# Altium schematic sheet SIB Ext Press.SchDoc
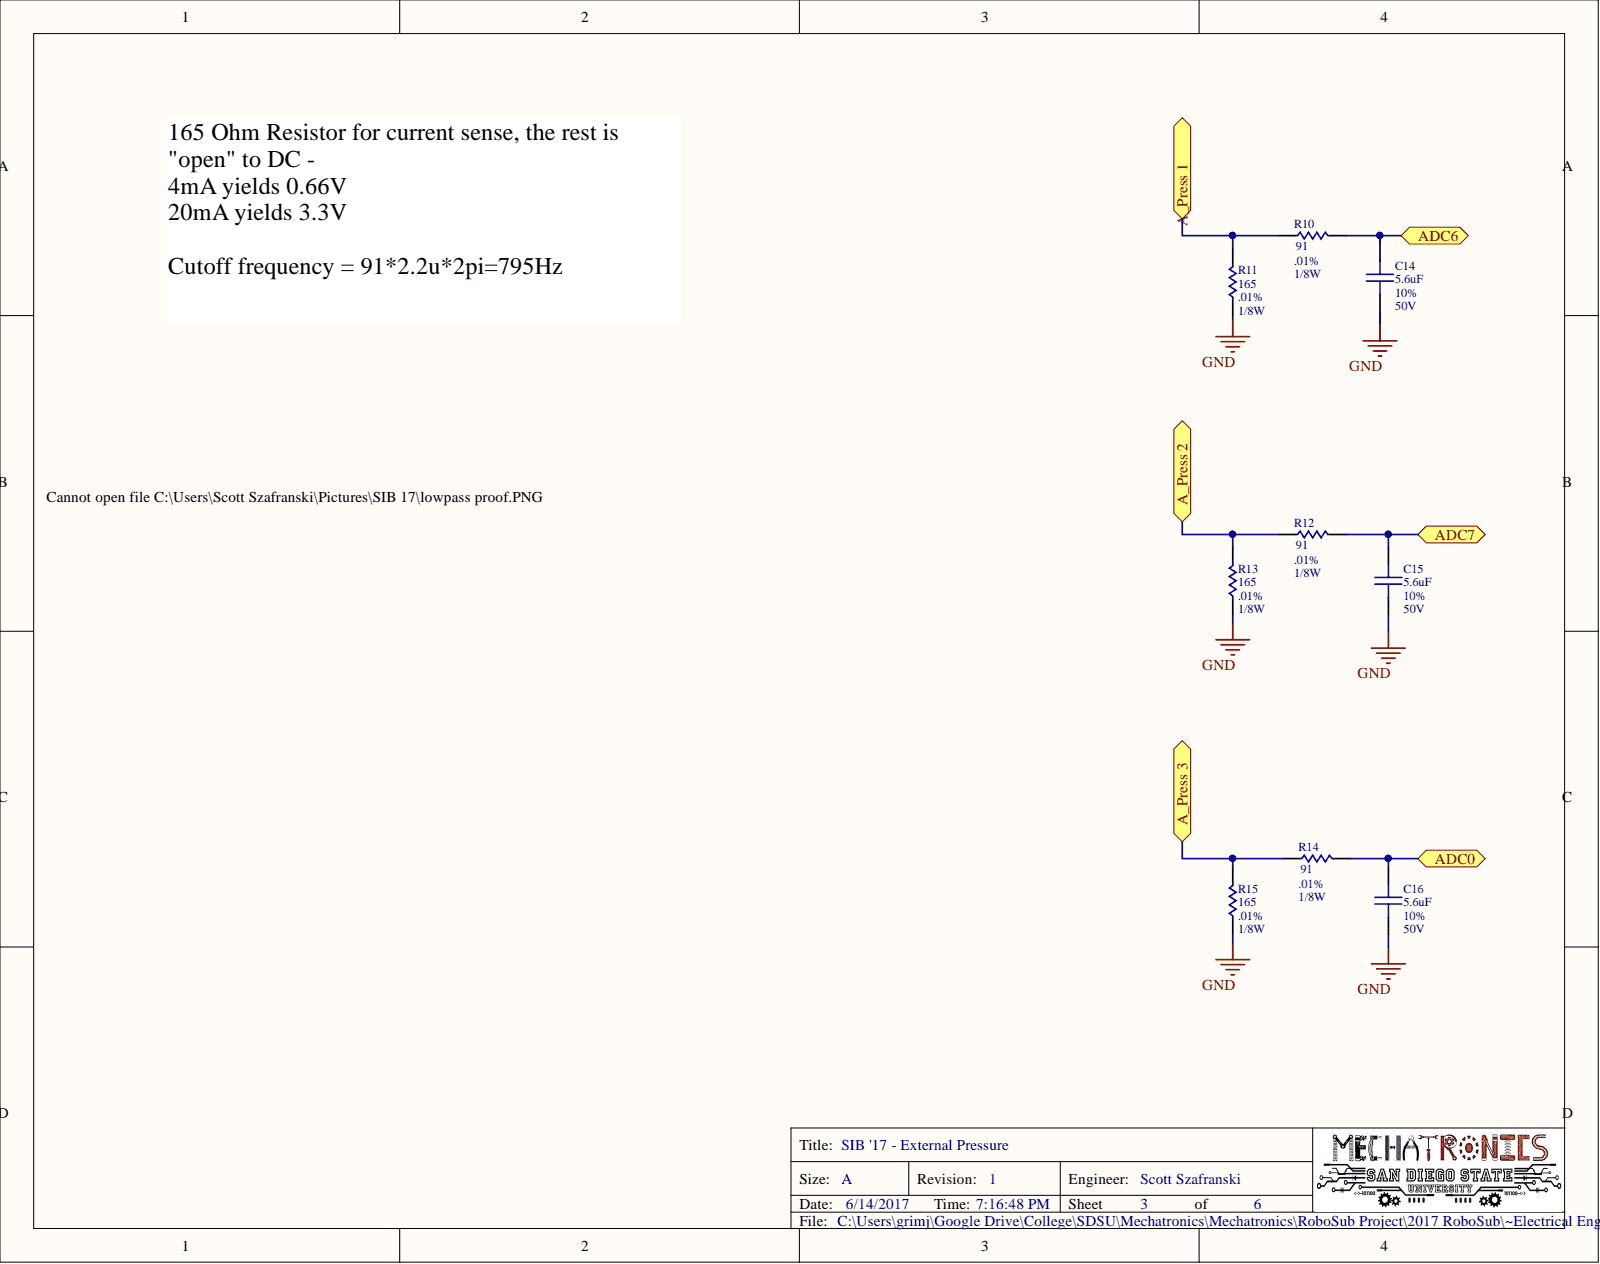
[diagram p0: SIB Ext Press.SchDoc]

## Parts
C14  5.6uF  Tolerance: 10%  Voltage: 50V  [Component 0805]
C15  5.6uF  Tolerance: 10%  Voltage: 50V  [Component 0805]
C16  5.6uF  Tolerance: 10%  Voltage: 50V  [Component 0805]
R10  91  Tolerance: .01%  [Component 0805]
R11  165  Power: 1/8W  Tolerance: .01%  [Component 0805]
R12  91  Tolerance: .01%  [Component 0805]
R13  165  Power: 1/8W  Tolerance: .01%  [Component 0805]
R14  91  Tolerance: .01%  [Component 0805]
R15  165  Power: 1/8W  Tolerance: .01%  [Component 0805]

## Ports
A_PRESS 1, A_PRESS 2, A_PRESS 3, ADC0, ADC6, ADC7

## Nets
GND: C14.2 C15.2 C16.2 R11.1 R13.1 R15.1
ADC0: C16.1 R14.2 ; port ADC0
ADC6: C14.1 R10.2 ; port ADC6
ADC7: C15.1 R12.2 ; port ADC7
NetR10_1: R10.1 R11.2 ; port A_PRESS 1
NetR12_1: R12.1 R13.2 ; port A_PRESS 2
NetR14_1: R14.1 R15.2 ; port A_PRESS 3

## Library data of the parts
C14-C16 = Capacitor, Ceramic: Capacitance: 5.6uF; Component Kind: Standard; Library Name: MechatronicsSCH.SchLib
R10 R12 R14 = Resistor: Thick Film Resistors - SMD 1/16watt 1%; Power: 1/8W; Component Kind: Standard; Resistance: 91; Library Name: MechatronicsSCH.SchLib
R11 R13 R15 = Resistor: Thick Film Resistors - SMD 1/16watt 1%; Component Kind: Standard; Resistance: 165; Library Name: MechatronicsSCH.SchLib
